# Altium schematic sheet FSMSequence_SCH.SchDoc(FSMSequence_SCH)
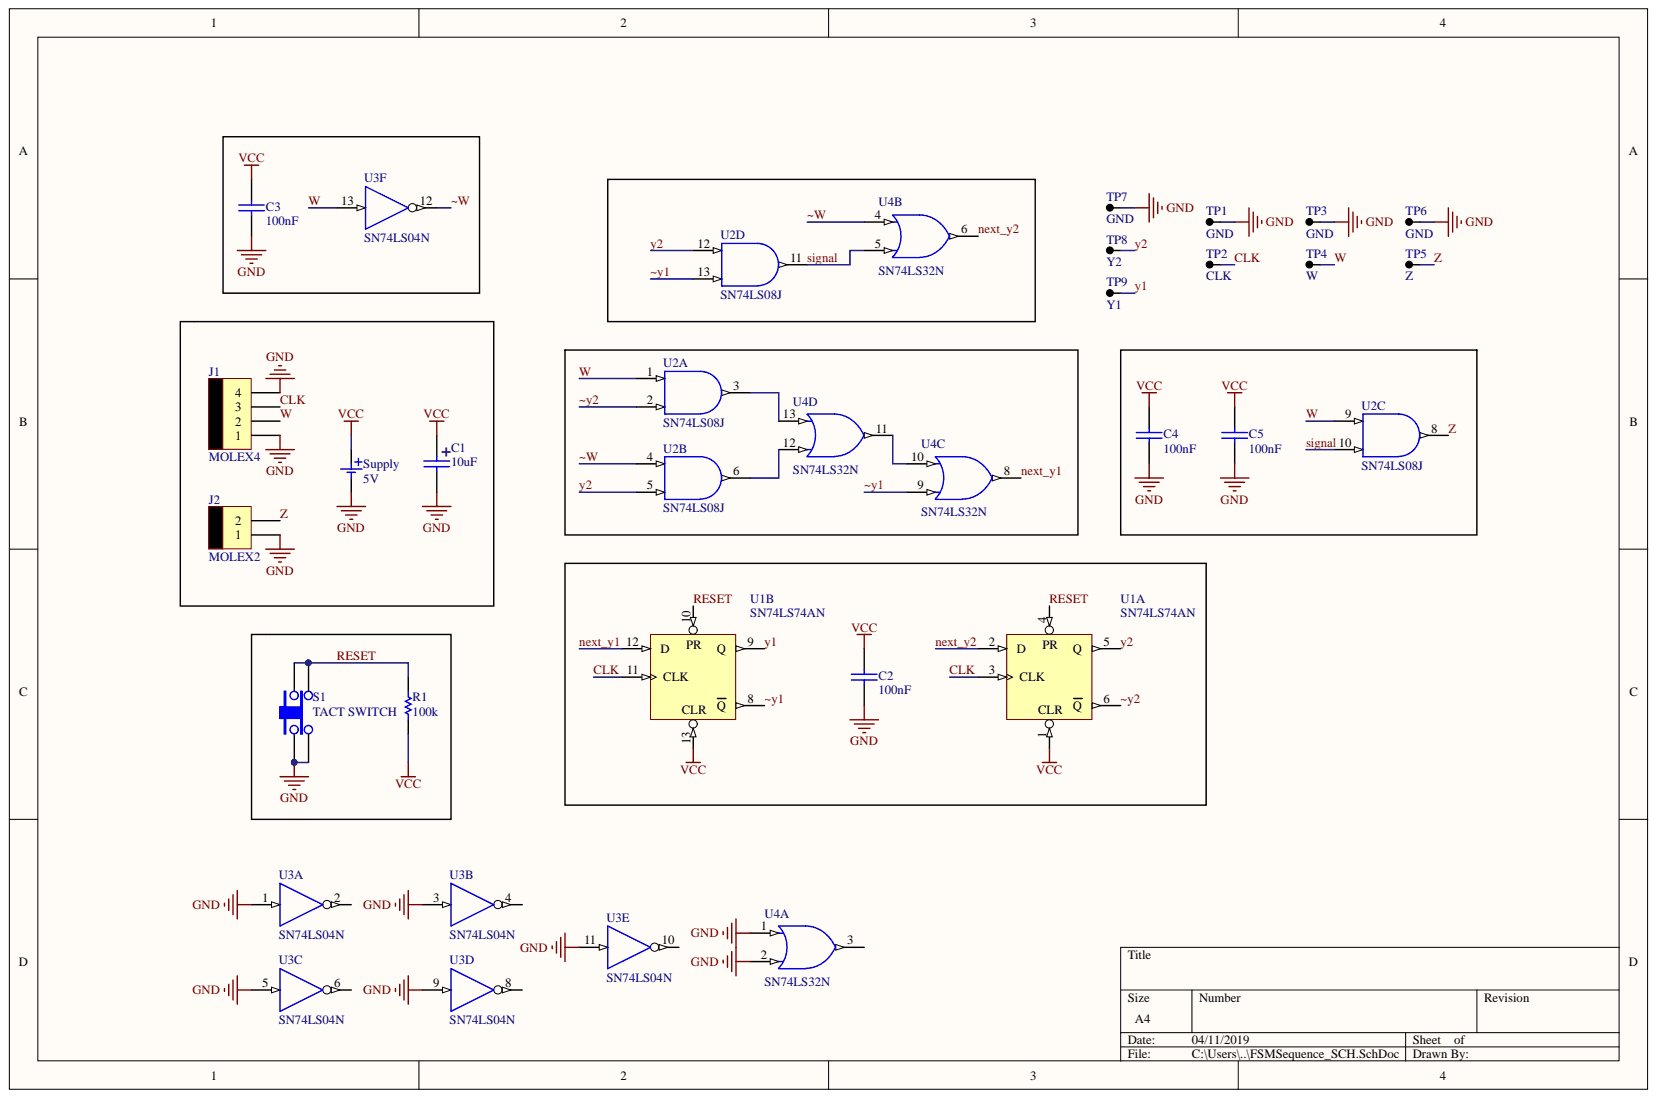
[diagram p0: FSMSequence_SCH.SchDoc]

## Parts
C1  10uF  [THT]
C2  100nF  [SMD0805]
C3  100nF  [SMD0805]
C4  100nF  [SMD0805]
C5  100nF  [SMD0805]
J1  MOLEX4  [ALOJAMIENTO POLARIZADO 4 PINES]
J2  MOLEX2  [ALOJAMIENTO POLARIZADO 2 PINES]
R1  100k  [SMD0805]
S1  TACT SWITCH  [PULSADOR]
Supply  5V  [BORNERA2]
TP1  GND  [TPTH]
TP2  CLK  [TPTH]
TP3  GND  [TPTH]
TP4  W  [TPTH]
TP5  Z  [TPTH]
TP6  GND  [TPTH]
TP7  GND  [TPTH]
TP8  Y2  [TPTH]
TP9  Y1  [TPTH]
U1  SN74LS74AN  [DIP14]  (parts A,B)
U2  SN74LS08J  [DIP14]  (parts A,B,C,D)
U3  SN74LS04N  [DIP14]  (parts A,B,C,D,E,F)
U4  SN74LS32N  [DIP14]  (parts A,B,C,D)

## Nets
GND: C1.2 C2.2 C3.2 C4.2 C5.2 J1.1 J1.4 J2.1 S1.1 S1.2 Supply.2 TP1.1 TP3.1 TP6.1 TP7.1 U1.7 U2.7 U3.1 U3.3 U3.5 U3.7 U3.9 U3.11 U4.1 U4.2 U4.7
VCC: C1.1 C2.1 C3.1 C4.1 C5.1 R1.1 Supply.1 U1.1 U1.13 U1.14 U2.14 U3.14 U4.14
CLK: J1.3 TP2.1 U1.3(CLK) U1.11(CLK)
NEXT_Y1: U1.12(D) U4.8
NEXT_Y2: U1.2(D) U4.6
RESET: R1.2 S1.3 S1.4 U1.4(PR) U1.10(PR)
SIGNAL: U2.10 U2.11 U4.5
W: J1.2 TP4.1 U2.1 U2.9 U3.13
Y1: TP9.1 U1.9(Q)
Y2: TP8.1 U1.5(Q) U2.5 U2.12
Z: J2.2 TP5.1 U2.8
~W: U2.4 U3.12 U4.4
~Y1: U1.8(Q) U2.13 U4.9
~Y2: U1.6(Q) U2.2
NetU2_3: U2.3 U4.13
NetU2_6: U2.6 U4.12
NetU4_10: U4.10 U4.11

Not connected: U3 2 4 6 8 10; U4 3

## Library data of the parts
C1 = CAPPOL: Component Kind: Standard; Library Name: LIBEBAL.IntLib; Value: 10uF
C2-C5 = CAP: Component Kind: Standard; Library Name: LIBEBAL.IntLib; Value: 100nF
J1 = MOLEX4: Value: ALOJAMIENTO POLARIZADO 4 PINES; Component Kind: Standard; Library Name: LIBEBAL.IntLib
J2 = MOLEX2: Value: ALOJAMIENTO POLARIZADO 2 PINES; Component Kind: Standard; Library Name: LIBEBAL.IntLib
R1 = RES: Component Kind: Standard; Library Name: LIBEBAL.IntLib; Value: 100k
S1 = TACT SWITCH: Value: PULSADOR; Component Kind: Standard; Library Name: LIBEBAL.IntLib
Supply = BATERIA: Value: BORNERA2; Component Kind: Standard; Description: BATERIA; Library Name: LIBEBAL.IntLib
TP1-TP9 = TP: Component Kind: Standard; Library Name: LIBEBAL.IntLib
U1 = SN74LS74AN: Dual D-Type Positive-Edge-Triggered Flip-Flop with Preset and Clear; Value: 74LS74; Component Kind: Standard; Library Name: TI Logic Flip-Flop.IntLib; PCB3D: N014; Signal Integrity: SN74LS74AN; Simulation: SN74LS74
U2 = SN74LS08J: Quadruple 2-Input Positive-AND Gate; Value: 74LS08; Component Kind: Standard; Library Name: TI Logic Gate 2.IntLib; PCB3D: J014; Signal Integrity: SN74LS08J; Simulation: SN74LS08
U3 = SN74LS04N: Hex Inverter; Value: 74LS04; Component Kind: Standard; Library Name: TI Logic Gate 2.IntLib; PCB3D: N014; Signal Integrity: SN74LS04N; Simulation: SN74LS04
U4 = SN74LS32N: Quadruple 2-Input Positive-OR Gate; Value: 74LS32; Component Kind: Standard; Library Name: TI Logic Gate 2.IntLib; PCB3D: N014; Signal Integrity: SN74LS32N; Simulation: SN74LS32
